# Altium schematic sheet Comprator.SchDoc(Comprator)
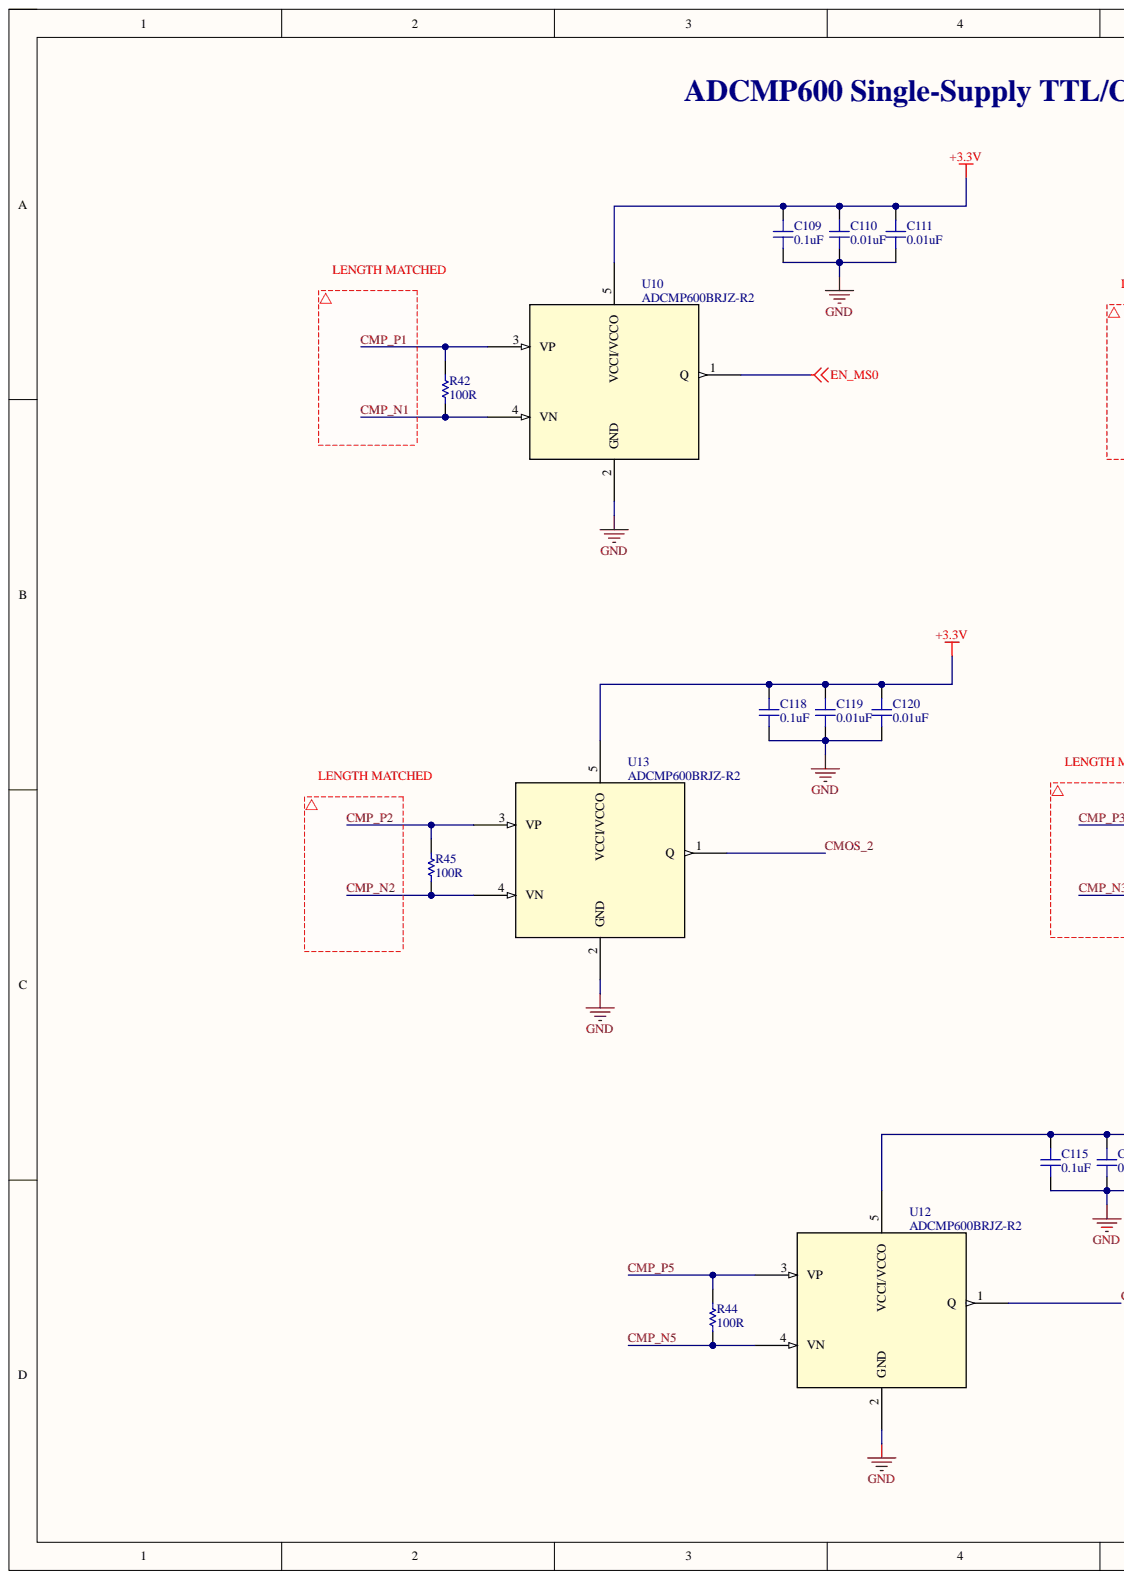
[diagram p0: Comprator.SchDoc, left]
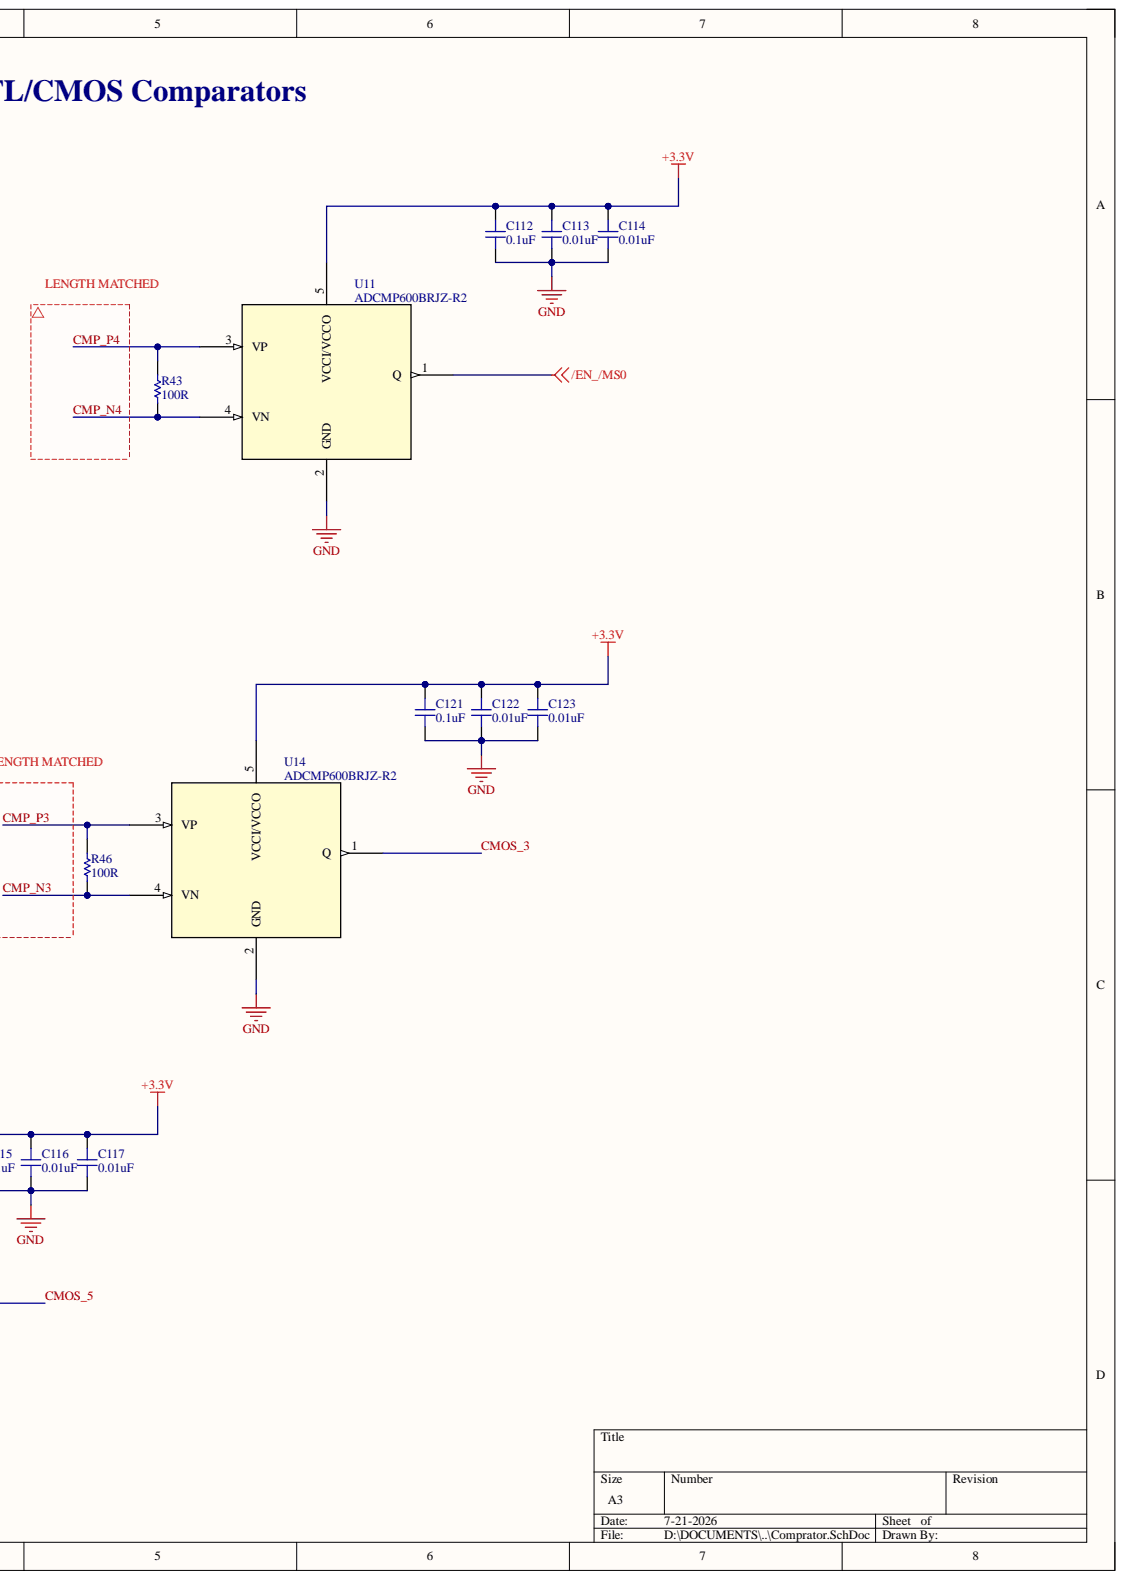
[diagram p1: Comprator.SchDoc, right]

## Parts
C109  0.1uF  [CAP_0402]
C110  0.01uF  [CAP_0402]
C111  0.01uF  [CAP_0402]
C112  0.1uF  [CAP_0402]
C113  0.01uF  [CAP_0402]
C114  0.01uF  [CAP_0402]
C115  0.1uF  [CAP_0402]
C116  0.01uF  [CAP_0402]
C117  0.01uF  [CAP_0402]
C118  0.1uF  [CAP_0402]
C119  0.01uF  [CAP_0402]
C120  0.01uF  [CAP_0402]
C121  0.1uF  [CAP_0402]
C122  0.01uF  [CAP_0402]
C123  0.01uF  [CAP_0402]
R42  100R  [RES_0402]
R43  100R  [RES_0402]
R44  100R  [RES_0402]
R45  100R  [RES_0402]
R46  100R  [RES_0402]
U10  ADCMP600BRJZ-R2  [RJ_5_ADI-L]
U11  ADCMP600BRJZ-R2  [RJ_5_ADI-L]
U12  ADCMP600BRJZ-R2  [RJ_5_ADI-L]
U13  ADCMP600BRJZ-R2  [RJ_5_ADI-L]
U14  ADCMP600BRJZ-R2  [RJ_5_ADI-L]

## Nets
+3.3V: C109.2 C110.2 C111.2 C112.2 C113.2 C114.2 C115.2 C116.2 C117.2 C118.2 C119.2 C120.2 C121.2 C122.2 C123.2 U10.5(VCCI/VCCO) U11.5(VCCI/VCCO) U12.5(VCCI/VCCO) U13.5(VCCI/VCCO) U14.5(VCCI/VCCO)
/EN_/MS0: U11.1(Q)
EN_MS0: U10.1(Q)
GND: C109.1 C110.1 C111.1 C112.1 C113.1 C114.1 C115.1 C116.1 C117.1 C118.1 C119.1 C120.1 C121.1 C122.1 C123.1 U10.2(GND) U11.2(GND) U12.2(GND) U13.2(GND) U14.2(GND)
CMOS_2: U13.1(Q)
CMOS_3: U14.1(Q)
CMOS_5: U12.1(Q)
CMP_N1: R42.1 U10.4(VN)
CMP_N2: R45.1 U13.4(VN)
CMP_N3: R46.1 U14.4(VN)
CMP_N4: R43.1 U11.4(VN)
CMP_N5: R44.1 U12.4(VN)
CMP_P1: R42.2 U10.3(VP)
CMP_P2: R45.2 U13.3(VP)
CMP_P3: R46.2 U14.3(VP)
CMP_P4: R43.2 U11.3(VP)
CMP_P5: R44.2 U12.3(VP)

## Library data of the parts
C109-C123 = CAP_0402_25V: C0402_25V; Component Kind: Standard; Library Name: Microwave.SchLib
R42-R46 = RES_0402: R0402; Component Kind: Standard; Library Name: Microwave.SchLib
U10-U14 = ADCMP600BRJZ-R2: Rail-to-Rail, Very Fast, 2.5 V to 5.5 V, Single-Supply TTL/CMOS Comparator in 5-lead SC70 and SOT-23 Packages; Manufacturer_Name: Analog Devices Inc; Component Kind: Standard; Library Name: SamacSys.SchLib; Manufacturer_Part_Number: ADCMP600BRJZ-R2; RefDes: RefDes; Type: Type; Copyright: Copyright (C) 2026 Ultra Librarian. All rights reserved.
